# Altium schematic sheet pca20054_sheet4_power_control.SchDoc
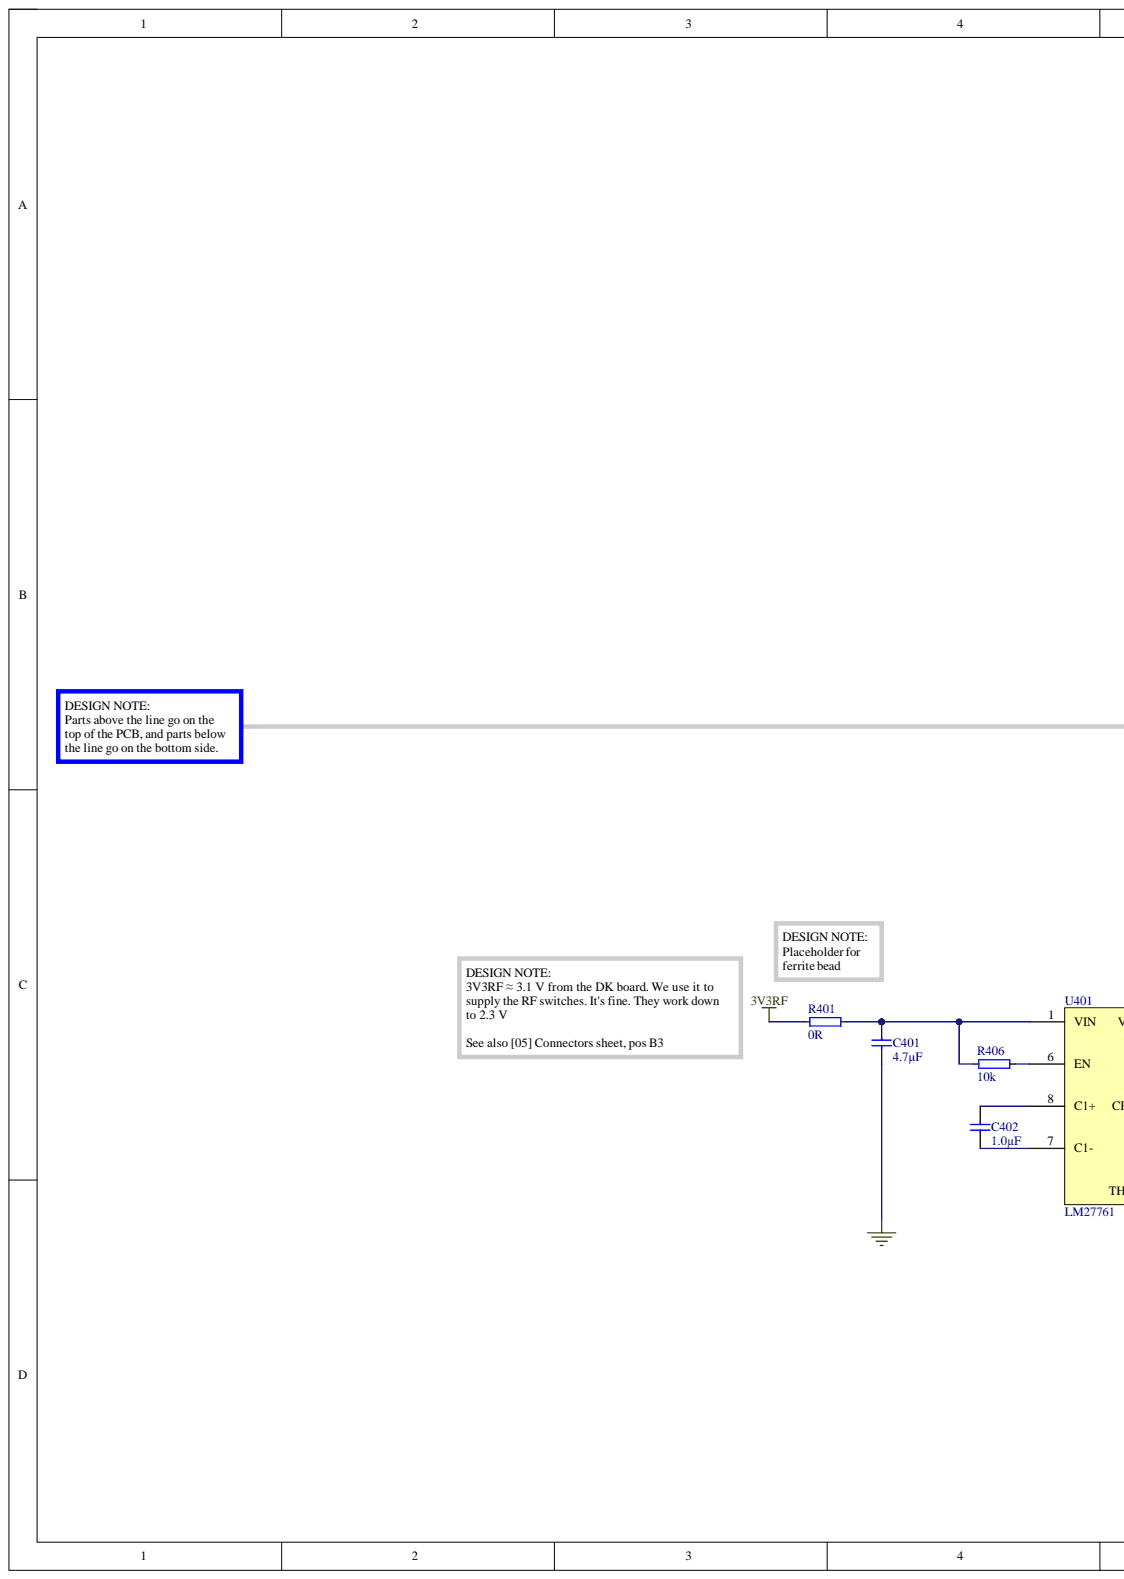
[diagram p0: pca20054_sheet4_power_control.SchDoc, left]
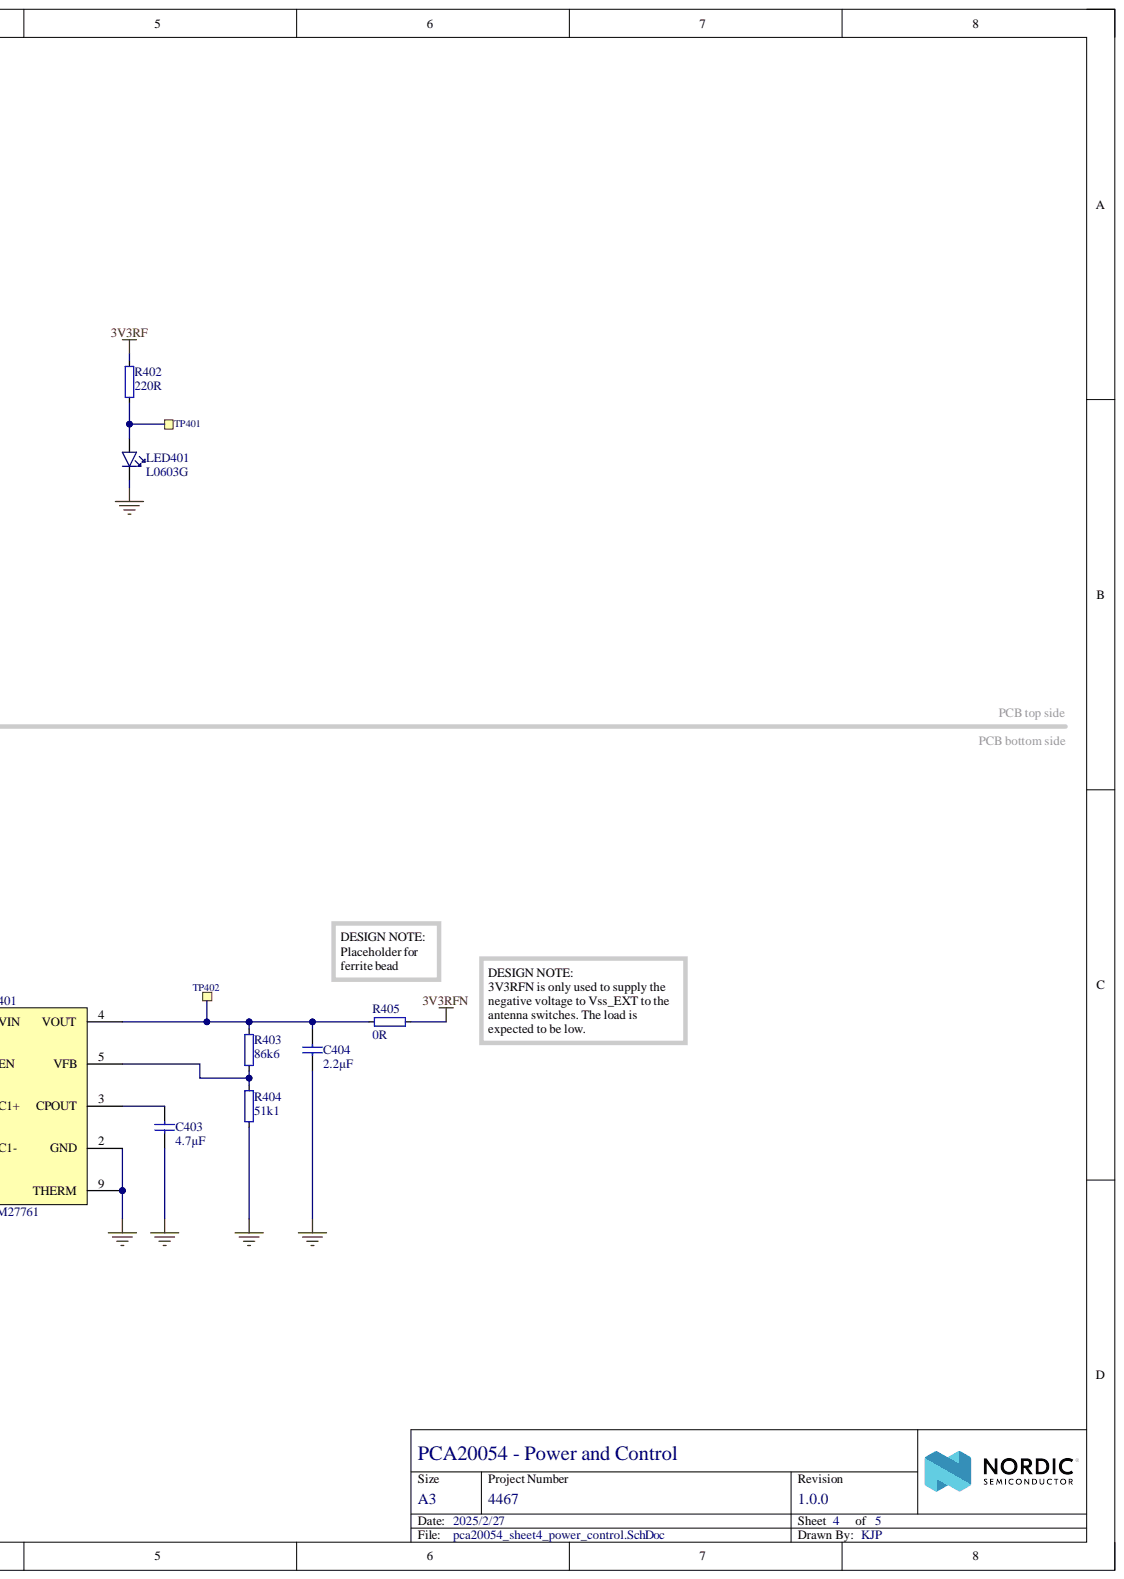
[diagram p1: pca20054_sheet4_power_control.SchDoc, right]

## Parts
C401  4.7µF  [CAPC1608X06L]
C402  1.0µF  [CAPC1608X06L]
C403  4.7µF  [CAPC1608X06L]
C404  2.2µF  [CAPC1608X06L]
LED401  L0603G  [LED_0603_G]
R401  0R  [RESC1005X04L]
R402  220R  [RESC1005X04L]
R403  86k6  [RESC1005X04L]
R404  51k1  [RESC1005X04L]
R405  0R  [RESC1005X04L]
R406  10k  [RESC1005X04L]
TP401  Ø1.0mm,SMD  [TP_SMD_?.0mm]  (value not drawn)  (no BOM)
TP402  Ø1.0mm,SMD  [TP_SMD_?.0mm]  (value not drawn)  (no BOM)
U401  LM27761  [SON50P200X80_HS-9L]

## Nets
3V3RF: R401.1 R402.2
3V3RFN: R405.2
GND: C401.1 C403.1 C404.1 LED401.1 R404.1 U401.2(GND) U401.9(THERM)
NetC401_2: C401.2 R401.2 R406.1 U401.1(VIN)
NetC402_1: C402.1 U401.7(C1-)
NetC402_2: C402.2 U401.8(C1+)
NetC403_2: C403.2 U401.3(CPOUT)
NetC404_2: C404.2 R403.2 R405.1 TP402.1 U401.4(VOUT)
NetLED401_2: LED401.2 R402.1 TP401.1
NetR403_1: R403.1 R404.2 U401.5(VFB)
NetR406_2: R406.2 U401.6(EN)

## Library data of the parts
C401 C403 = C0603-4µ7: Capacitor, X5R, ±10%; Part number IPS: 00000007783; Artikkelnr Noca: 20069060; Database Table Name: Capacitors$; Note: 6.3V; Component Kind: Standard; ComponentLink1Description: Datasheet; ComponentLink2Description: Supplier link; Footprint Doc: 0603; LatestRevisionDate: 2009-11-23; Library Name: Nordic Passive DB.DbLib; Part Number: C0603-4µ7; Pin Count: 2; Published: 2009-05-08; Value: 4.7µF; Publisher: Nordic Semiconductor
C402 = C0603-1µ0: Capacitor, X7R, ±10%; Part number IPS: 00000000616; Artikkelnr Noca: 20039282; Database Table Name: Capacitors$; Note: 16V; Component Kind: Standard; ComponentLink1Description: Datasheet; ComponentLink2Description: Supplier link; Footprint Doc: 0603; LatestRevisionDate: 2013-06-05; Library Name: Nordic Passive DB.DbLib; Part Number: C0603-1µ0; Pin Count: 2; Published: 2009-05-08; Value: 1.0µF; Publisher: Nordic Semiconductor
C404 = C0603-2µ2: Capacitor, X7R, ±10%; Part number IPS: N.A.; Artikkelnr Noca: 20039322; Database Table Name: Capacitors$; Note: 6.3V; Component Kind: Standard; ComponentLink1Description: Datasheet; ComponentLink2Description: Supplier link; Footprint Doc: 0603; LatestRevisionDate: 2016-10-10; Library Name: Nordic Passive DB.DbLib; Part Number: C0603-2µ2; Pin Count: 2; Published: 2009-05-08; Value: 2.2µF; Publisher: Nordic Semiconductor
LED401 = LG L29K Smartled Green: LED, Green, 0603, 570nm, Vf=1.7V, 2.24..5.6mcd, - 40 to +100°C; Manufacturer: Osram; Manufacturer Part Number: LG L29K-G2J1-24-Z; Part number IPS: 00000009961; Artikkelnr Noca: 13019147; Database Table Name: Optoelectronics$; Note: 2.24..5.6 mcd, Vfmin=1.7V; Component Kind: Standard; ComponentLink1Description: Datasheet; ComponentLink2Description: Supplier link; Footprint Doc: 0603; HelpURL: http://www.osram-os.com/Graphics/XPic2/00077107_0.pdf; LatestRevisionDate: 2017-08-21; Library Name: Nordic Discrete DB.DbLib; Part Number: LG L29K Smartled Green; Pin Count: 2; Published: 2014-10-28; Supplier 1: Digi-Key; Supplier 2: Mouser; Supplier Part Number 1: 475-2709-1-ND; Supplier Part Number 2: 720-LGL29KG2J124Z; Value: L0603G; Publisher: Nordic Semiconductor
R401 R405 = R0402-0R: Resistor, ±5%, 0.063W; Part number IPS: 00000007128; Artikkelnr Noca: 27049000; Database Table Name: Resistors$; Note: Chip Res 5%; Component Kind: Standard; ComponentLink1Description: Datasheet; ComponentLink2Description: Supplier link; Footprint Doc: 0402; LatestRevisionDate: 2009-11-23; Library Name: Nordic Passive DB.DbLib; Part Number: R0402-0R; Pin Count: 2; Published: 2009-05-08; Supplier 1: Farnell; Supplier Part Number 1: 146-9661; Value: 0R; Publisher: Nordic Semiconductor
R402 = R0402-220: Resistor, ±1%, 0.063W; Part number IPS: N.A.; Artikkelnr Noca: 27049057; Database Table Name: Resistors$; Note: Chip Res 1%; Component Kind: Standard; ComponentLink1Description: Datasheet; ComponentLink2Description: Supplier link; Footprint Doc: 0402; LatestRevisionDate: 2009-11-23; Library Name: Nordic Passive DB.DbLib; Part Number: R0402-220; Pin Count: 2; Published: 2009-05-08; Supplier 1: Farnell; Supplier Part Number 1: 173-8841; Value: 220R; Publisher: Nordic Semiconductor
R403 = R0402-86k6: Resistor, ±1%, 0.063W; Manufacturer: Panasonic; Manufacturer Part Number: ERJ-2RKF8662X; Part number IPS: N.A.; Artikkelnr Noca: 27049119; Database Table Name: Resistors$; Note: Chip Res 1%; Component Kind: Standard; ComponentLink1Description: Datasheet; ComponentLink2Description: Supplier link; Footprint Doc: 0402; LatestRevisionDate: 2009-11-23; Library Name: Nordic Passive DB.DbLib; Part Number: R0402-86k6; Pin Count: 2; Published: 2009-05-08; Supplier 1: Digi-Key; Supplier 2: Mouser; Supplier Part Number 1: P86.6KLCT-ND; Supplier Part Number 2: 667-ERJ-2RKF8662X; Value: 86k6; Publisher: Nordic Semiconductor
R404 = R0402-51k1: Resistor, ±0.1%, 0.063W; Manufacturer: Panasonic; Manufacturer Part Number: ERA-2AEB5112X; Artikkelnr Noca: N.A.; Database Table Name: Resistors$; Note: Chip Res 1%; Component Kind: Standard; ComponentLink1Description: Datasheet; ComponentLink2Description: Supplier link; Footprint Doc: 0402; LatestRevisionDate: 2016-11-24; Library Name: Nordic Passive DB.DbLib; Part Number: R0402-51k1; Part number IPS: N.A.; Pin Count: 2; Published: 2016-11-24; Supplier 1: Digi-Key; Supplier 2: Mouser; Supplier Part Number 1: P51.1KDCCT-ND; Supplier Part Number 2: 667-ERA-2AEB5112X; Value: 51k1; Publisher: Nordic Semiconductor
R406 = R0402-10k: Resistor, ±1%, 0.063W; Part number IPS: 00000002093; Artikkelnr Noca: 27049097; Database Table Name: Resistors$; Note: Chip Res 1%; Component Kind: Standard; ComponentLink1Description: Datasheet; ComponentLink2Description: Supplier link; Footprint Doc: 0402; LatestRevisionDate: 2009-11-23; Library Name: Nordic Passive DB.DbLib; Part Number: R0402-10k; Pin Count: 2; Published: 2009-05-08; Supplier 1: Farnell; Supplier Part Number 1: 173-8864; Value: 10k; Publisher: Nordic Semiconductor
TP401 TP402 = TP_SMD_Ø1.0MM: 1.0mm circular SMD testpad; Manufacturer: Nordic Semiconductor; Artikkelnr Noca: N.A.; Database Table Name: Connectors$; ComponentLink1Description: Datasheet; ComponentLink2Description: Supplier link; Footprint Doc: TP_SMD_Ø1.0mm; LatestRevisionDate: 2013-06-11; Library Name: Nordic Passive DB.DbLib; Part Number: TP_SMD_Ø1.0MM; Part number IPS: N.A.; Pin Count: 1; Published: 2013-06-11; Value: Ø1.0mm,SMD; Publisher: Nordic Semiconductor
U401 = LM27761: Low-Noise, Regulated, Switched-Capacitor Voltage Inverter; Manufacturer: Texas Instruments; Artikkelnr Noca: N.A.; Database Table Name: Misc IC$; Note: DSGT: 250 pts reel; Component Kind: Standard; ComponentLink1Description: Datasheet; ComponentLink1URL: http://www.ti.com/lit/ds/symlink/lm27761.pdf; ComponentLink2Description: Supplier link; ComponentLink2URL: https://www.digikey.com/product-detail/en/texas-instruments/LM27761DSGT/296-43882-1-ND/5880550; Footprint Doc: WSON-8; HelpURL: https://www.ti.com/product/LM27761; LatestRevisionDate: 2020-01-17; Library Name: Nordic Active DB.DbLib; Manufacturer Part Number: LM27761; Part Number: LM27761; Part number IPS: N.A.; Pin Count: 8; Published: 2020-01-17; Supplier 1: Digi-Key; Supplier 2: Mouser; Supplier Part Number 1: 296-43882-1-ND; Supplier Part Number 2: 595-LM27761DSGR; Value: LM27761; Publisher: Nordic Semiconductor
